# Altium schematic sheet P01_PMIC.SchDoc(P01_PMIC)
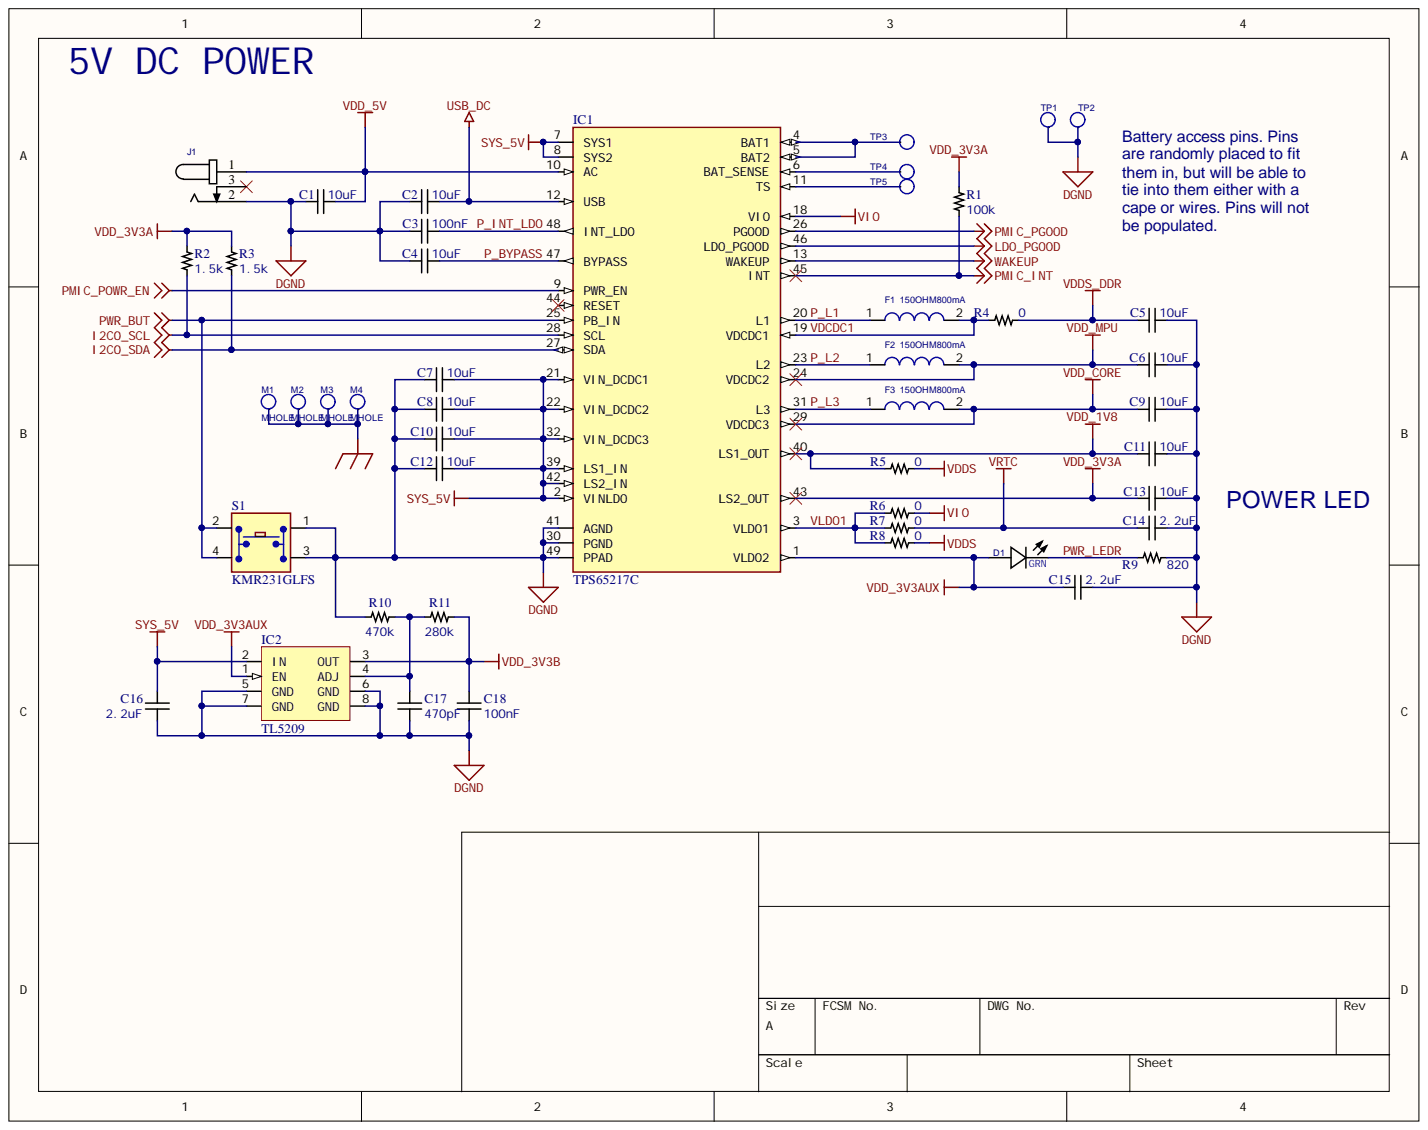
[diagram p0: P01_PMIC.SchDoc]

## Parts
C1  Capacitance: 10uF  [CAP0805]  (hidden comment: C2012X6S1A106K085AC)
C2  Capacitance: 10uF  [CAP0805]  (hidden comment: C2012X6S1A106K085AC)
C3  Capacitance: 100nF  [CAP0402]  (hidden comment: C0402C104K4RACTU)
C4  Capacitance: 10uF  [CAP0805]  (hidden comment: C2012X6S1A106K085AC)
C5  Capacitance: 10uF  [CAP0805]  (hidden comment: C2012X6S1A106K085AC)
C6  Capacitance: 10uF  [CAP0805]  (hidden comment: C2012X6S1A106K085AC)
C7  Capacitance: 10uF  [CAP0805]  (hidden comment: C2012X6S1A106K085AC)
C8  Capacitance: 10uF  [CAP0805]  (hidden comment: C2012X6S1A106K085AC)
C9  Capacitance: 10uF  [CAP0805]  (hidden comment: C2012X6S1A106K085AC)
C10  Capacitance: 10uF  [CAP0805]  (hidden comment: C2012X6S1A106K085AC)
C11  Capacitance: 10uF  [CAP0805]  (hidden comment: C2012X6S1A106K085AC)
C12  Capacitance: 10uF  [CAP0805]  (hidden comment: C2012X6S1A106K085AC)
C13  Capacitance: 10uF  [CAP0805]  (hidden comment: C2012X6S1A106K085AC)
C14  Capacitance: 2.2uF  [CAP0402]  (hidden comment: C1005X5R0J225K050BC)
C15  Capacitance: 2.2uF  [CAP0402]  (hidden comment: C1005X5R0J225K050BC)
C16  Capacitance: 2.2uF  [CAP0402]  (hidden comment: C1005X5R0J225K050BC)
C17  Capacitance: 470pF  [CAP0402]  (hidden comment: GRM155R71H471KA01D)
C18  Capacitance: 100nF  [CAP0402]  (hidden comment: C0402C104K4RACTU)
D1  598-8170-107F  [LED_0603]  (value not drawn)
F1  150OHM800mA  [FB0805]
F2  150OHM800mA  [FB0805]
F3  150OHM800mA  [FB0805]
IC1  TPS65217C  [PVQFN-48]
IC2  TL5209  [SOIC-8]
J1  PJ-200A  [CONN3-PWR-PJ102A]  (value not drawn)
M1  MHOLE  [MTGH200C125D_PL]  (no BOM)
M2  MHOLE  [MTGH200C125D_PL]  (no BOM)
M3  MHOLE  [MTGH200C125D_PL]  (no BOM)
M4  MHOLE  [MTGH200C125D_PL]  (no BOM)
R1  Resistance: 100k  [RES0402]  (hidden comment: RC0402FR-07100KL)
R2  Resistance: 1.5k  [RES0402]  (hidden comment: RC0402FR-071K5L)
R3  Resistance: 1.5k  [RES0402]  (hidden comment: RC0402FR-071K5L)
R4  RC0402JR-070RL  [RES0402]  (value not drawn)
R5  RC0402JR-070RL  [RES0402]  (value not drawn)
R6  RC0402JR-070RL  [RES0402]  (value not drawn)
R7  RC0402JR-070RL  [RES0402]  (value not drawn)
R8  RC0402JR-070RL  [RES0402]  (value not drawn)
R9  RC0402FR-07820RL  [RES0402]  (value not drawn)
R10  Resistance: 470k  [RES0402]  (hidden comment: RC0402FR-07470KL)
R11  Resistance: 280k  [RES0402]  (hidden comment: RC0402FR-07280KL)
S1  KMR231GLFS  [SW4SMD_63X161LS]
TP1  TESTPT1  [tp1-8040]  (value not drawn)  (no BOM)
TP2  TESTPT1  [tp1-8040]  (value not drawn)  (no BOM)
TP3  TESTPT1  [tp1-8040]  (value not drawn)  (no BOM)
TP4  TESTPT1  [tp1-8040]  (value not drawn)  (no BOM)
TP5  TESTPT1  [tp1-8040]  (value not drawn)  (no BOM)

## Nets
DGND: C1.2 C2.2 C3.2 C4.2 C5.1 C6.1 C7.2 C8.2 C9.1 C10.2 C11.1 C12.2 C13.1 C14.1 C15.1 C16.2 C17.2 C18.2 IC1.30(PGND) IC1.41(AGND) IC1.49(PPAD) IC2.5(GND) IC2.6(GND) IC2.7(GND) IC2.8(GND) J1.2 R9.2 R10.1 S1.1 S1.3 TP1.1 TP2.1
GND_EARTH: M1.1 M2.1 M3.1 M4.1
I2C0_SCL: IC1.28(SCL) R2.1
I2C0_SDA: IC1.27(SDA) R3.1
LDO_PGOOD: IC1.46(LDO_PGOOD)
PMIC_INT: IC1.45(INT) R1.1
PMIC_PGOOD: IC1.26(PGOOD)
PMIC_POWR_EN: IC1.9(PWR_EN)
PWR_BUT: IC1.25(PB_IN) S1.2 S1.4
SYS_5V: C7.1 C8.1 C10.1 C12.1 C16.1 IC1.2(VINLDO) IC1.7(SYS1) IC1.8(SYS2) IC1.21(VIN_DCDC1) IC1.22(VIN_DCDC2) IC1.32(VIN_DCDC3) IC1.39(LS1_IN) IC1.42(LS2_IN) IC2.2(IN)
USB_DC: C2.1 IC1.12(USB)
VDD_1V8: C11.2 IC1.40(LS1_OUT) R5.1
VDD_3V3A: C13.2 IC1.43(LS2_OUT) R1.2 R2.2 R3.2
VDD_3V3AUX: C15.2 D1.2 IC1.1(VLDO2) IC2.1(EN)
VDD_3V3B: C18.1 IC2.3(OUT) R11.2
VDD_5V: C1.1 IC1.10(AC) J1.1
VDD_CORE: C9.2 F3.2 IC1.29(VDCDC3)
VDD_MPU: C6.2 F2.2 IC1.24(VDCDC2)
VDDS: R5.2 R8.2
VDDS_DDR: C5.2 R4.2
VIO: IC1.18(VIO) R6.2
VRTC: C14.2 R7.2
WAKEUP: IC1.13(WAKEUP)
P_BYPASS: C4.1 IC1.47(BYPASS)
P_INT_LDO: C3.1 IC1.48(INT_LDO)
P_L1: F1.1 IC1.20(L1)
P_L2: F2.1 IC1.23(L2)
P_L3: F3.1 IC1.31(L3)
PWR_LEDR: D1.1 R9.1
VDCDC1: F1.2 IC1.19(VDCDC1) R4.1
VLDO1: IC1.3(VLDO1) R6.1 R7.1 R8.1
NetC17_1: C17.1 IC2.4(ADJ) R10.2 R11.1
NetIC1_4: IC1.4(BAT1) IC1.5(BAT2) TP3.1
NetIC1_6: IC1.6(BAT_SENSE) TP4.1
NetIC1_11: IC1.11(TS) TP5.1

Not connected: IC1 44(RESET); J1 3

## Library data of the parts
C1 C2 C4-C13 = CAP_10uF: MLCC 10uF 0805 SMD; Manufacturer: TDK; Voltage Rating (DC): 10V; Tolerance: 10%; Dielectric Material: Ceramic; Dielectric: X6S; Width: 0.049inch; Weight: 0.000194oz; Voltage Rating: 10V; Thickness: 0.037inch; Termination: SMD/SMT; Supplier Part Number 1: 445-7613-1-ND; Supplier 1: Digi-Key; Stock 1: 46852; RoHS: Yes; REACH SVHC: No SVHC; Pricing 1: 1=0.30000, 10=0.20500, 100=0.11610, 500=0.08198, 1000=0.07173 (USD); Packaging: Tape and Reel; Package Quantity: 4000; Mount: Surface Mount; Min Operating Temperature: -55°C; Max Operating Temperature: 105°C; Manufacturer Part Number: C2012X6S1A106K085AC; Length: 2mm; Lead Free: Lead Free; Height: 1.25mm; Depth: 1.25mm; Composition: Ceramic; ComponentLink3URL: https://datasheet.ciiva.com/6290/tdkmlcccaprange-6290501.pdf?src-supplier=Digi-Key; ComponentLink3Description: Datasheet; Component Kind: Standard; Case Code (Metric): 2012; Case Code (Imperial): 0805; Case/Package: 0805; Library Name: Orient.SchLib
C3 C18 = CAP_100nF: MLCC 100nF 0402 SMD; Manufacturer: KEMET; Voltage Rating (DC): 16V; Tolerance: 10%; Dissipation Factor: 3.5%; Dielectric: X7R; Width: 0.5mm; Voltage Rating: 16V; Termination: SMD/SMT; Supplier Part Number 1: 399-3521-1-ND; Supplier 1: Digi-Key; Stock 1: 6512243; RoHS: Yes; Radiation Hardening: No; Pricing 1: 1=0.10000, 10=0.04200, 100=0.01860, 500=0.01328, 1000=0.01044, 2500=0.00949, 5000=0.00873 (USD); Packaging: Tape and Reel; Package Quantity: 10000; Mount: Surface Mount; Min Operating Temperature: -55°C; Max Operating Temperature: 125°C; Manufacturer Part Number: C0402C104K4RACTU; Length: 1mm; Height: 0.5mm; Depth: 0.5mm; Contact Plating: Tin,Matte; ComponentLink2URL: https://datasheet.ciiva.com/18192/getdatasheetpartid-385384-18192412.pdf?src-supplier=Verical; ComponentLink2Description: Datasheet; Component Kind: Standard; Case Code (Metric): 1005; Case Code (Imperial): 0402; Case/Package: 0402; Library Name: Orient.SchLib
C14-C16 = CAP_2.2uF: MLCC 2.2uF 0402 SMD; Manufacturer: TDK; Voltage Rating (DC): 6.3V; Tolerance: 10%; Dielectric Material: Ceramic; Dielectric: X5R; Width: 0.02inch; Weight: 2.3E-05oz; Voltage Rating: 6.3V; Voltage: 6.3V; Thickness: 0.022inch; Termination: SMD/SMT; Supplier 1: Digi-Key; Stock 1: 256055; RoHS: Yes; REACH SVHC: No SVHC; Radiation Hardening: No; Pricing 1: 1=0.20000, 10=0.13800, 100=0.07010, 500=0.04794, 1000=0.04240, 2500=0.04056, 5000=0.03687 (USD); Packaging: Tape and Reel; Package Quantity: 1; Number of Pins: 2; Mount: Surface Mount; Min Operating Temperature: -55°C; Max Operating Temperature: 85°C; Manufacturer Part Number: C1005X5R0J225K050BC; Length: 1mm; Lead Free: Lead Free; Height: 0.5mm; Depth: 0.5mm; Composition: Ceramic; ComponentLink7URL: https://product.tdk.com/info/en/catalog/datasheets/mlcc_commercial_general_en.pdf?ref_disty=digikey; ComponentLink7Description: Datasheet; Component Kind: Standard; Case Code (Metric): 1005; Case Code (Imperial): 0402; Case/Package: 0402; Library Name: Orient.SchLib
C17 = CAP_470pF: MLCC 470pF 0402 SMD; Manufacturer: Murata; Voltage Rating (DC): 50V; Tolerance: 10%; Material: Ceramic; Dielectric: X7R; Width: 0.02inch; Voltage Rating: 50V; Voltage: 50V; Thickness: 0.022inch; Termination: SMD/SMT; Supplier Part Number 1: 490-1300-1-ND; Supplier 1: Digi-Key; Stock 1: 1824670; RoHS: Yes; REACH SVHC: No SVHC; Radiation Hardening: No; Pricing 1: 1=0.10000, 10=0.04900, 100=0.02200, 500=0.01572, 1000=0.01235, 2500=0.01122, 5000=0.01033 (USD); Packaging: Tape and Reel; Package Quantity: 1; Number of Pins: 2; Mount: Surface Mount; Min Operating Temperature: -55°C; Max Operating Temperature: 125°C; Manufacturer Part Number: GRM155R71H471KA01D; Length: 1mm; Lead Free: Lead Free; Height: 0.5mm; Depth: 0.5mm; ComponentLink11URL: https://datasheet.ciiva.com/7937/608985-7937630.pdf?src-supplier=Element14; ComponentLink11Description: https://datasheet.ciiva.com/7937/608985-7937630.pdf?src-supplier=Element14; ComponentLink10URL: https://datasheet.ciiva.com/16199/product-16199180.pdf?src-supplier=Future+Electronics; ComponentLink10Description: https://datasheet.ciiva.com/16199/product-16199180.pdf?src-supplier=Future+Electronics; ComponentLink9URL: https://datasheet.ciiva.com/22698/608985-22698425.pdf?src-supplier=Element14; ComponentLink9Description: https://datasheet.ciiva.com/22698/608985-22698425.pdf?src-supplier=Element14; ComponentLink8URL: https://datasheet.ciiva.com/14116/grmseries-highdielectrictype-eng-14116837.pdf?src-supplier=Distrelec; ComponentLink8Description: https://datasheet.ciiva.com/14116/grmseries-highdielectrictype-eng-14116837.pdf?src-supplier=Distrelec; ComponentLink7URL: https://datasheet.ciiva.com/129/partnumbering-e-01-129845.pdf?src-supplier=Digi-Key; ComponentLink7Description: https://datasheet.ciiva.com/129/partnumbering-e-01-129845.pdf?src-supplier=Digi-Key; ComponentLink6URL: https://datasheet.ciiva.com/129/partnumbering-e-01-129844.pdf?src-supplier=Digi-Key; ComponentLink6Description: https://datasheet.ciiva.com/129/partnumbering-e-01-129844.pdf?src-supplier=Digi-Key; ComponentLink5URL: https://datasheet.ciiva.com/129/partnumbering-e-01-129843.pdf?src-supplier=Digi-Key; ComponentLink5Description: https://datasheet.ciiva.com/129/partnumbering-e-01-129843.pdf?src-supplier=Digi-Key; ComponentLink4URL: https://datasheet.ciiva.com/29199/454340-da-01-en-vs-kondensator-0402-29199656.pdf?src-supplier=Conrad; ComponentLink4Description: https://datasheet.ciiva.com/29199/454340-da-01-en-vs-kondensator-0402-29199656.pdf?src-supplier=Conrad; ComponentLink3URL: https://datasheet.ciiva.com/19476/1856505-19476137.pdf?src-supplier=Jameco; ComponentLink3Description: https://datasheet.ciiva.com/19476/1856505-19476137.pdf?src-supplier=Jameco; ComponentLink2URL: https://datasheet.ciiva.com/18101/getdatasheetpartid-37722-18101030.pdf?src-supplier=Verical; ComponentLink2Description: https://datasheet.ciiva.com/18101/getdatasheetpartid-37722-18101030.pdf?src-supplier=Verical; ComponentLink1URL: https://datasheet.ciiva.com/11786/0900766b80ef921d-11786912.pdf?src-supplier=RSComponents; ComponentLink1Description: https://datasheet.ciiva.com/11786/0900766b80ef921d-11786912.pdf?src-supplier=RSComponents; Component Kind: Standard; Case Code (Metric): 1005; Case Code (Imperial): 0402; Case/Package: 0402; Library Name: Orient.SchLib
D1 = LED_LTST-C150GKT: LED GREEN CLEAR 0805 SMD; Manufacturer Part Number: LTST-C170KGKT; Manufacturer: Lite-On Inc; Wavelength - Peak: 574nm; Wavelength - Dominant: 571nm; Voltage - Forward (Vf) Typ: 2V; Viewing Angle: 130°; TN: 257-0020-000; Millicandela Rating: 35mcd; Lens Style/Size: Rectangle with Flat Top, 1.4mm x 1.25mm; Current - Test: 20mA; CLASS: DISCRETE; Supplier Part Number 1: 160-1414-6-ND; Supplier 1: Digi-Key; Stock 1: 274890; Size / Dimension: 2.00mm L x 1.25mm W; RoHS: RoHS Compliant; Pricing 1: 1=0.37, 10=0.267, 100=0.1574, 250=0.11136, 500=0.09504, 1000=0.07425 (USD); Packaging: Digi-Reel®; Package / Case: 0805 (2012 Metric); Mounting Type: Surface Mount; Luminous Flux @ Current - Test: -; Lens Type: Clear; Height: 1.10mm; ComponentLink1URL: http://optoelectronics.liteon.com/en-us/api/DwonloadFileHandler.ashx?txtSpecNo=DS22-2000-073&txtPartNo=LTST-C170KGKT; ComponentLink1Description: http://optoelectronics.liteon.com/en-us/api/DwonloadFileHandler.ashx?txtSpecNo=DS22-2000-073&txtPartNo=LTST-C170KGKT; Component Kind: Standard; Color: Green; Category: Optoelectronics; Library Name: Orient.SchLib
F1-F3 = IND: FERRITE 800MA 150 OHM 0805 SMD; Manufacturer Part Number: LI0805H151R-10; Manufacturer: Laird-Signal Integrity Products; Impedance @ Frequency: 150 Ohm @ 100MHz; Filter Type: Differential Mode - Single; DC Resistance (DCR): 150 mOhm Max; Supplier Part Number 1: 240-2384-6-ND; Supplier 1: Digi-Key; Stock 1: 10144; Size / Dimension: 0.079" L x 0.049" W (2.00mm x 1.25mm); RoHS: RoHS Compliant; Pricing 1: 1=0.1, 10=0.07, 25=0.064, 50=0.0574, 100=0.0421, 250=0.03064, 500=0.02808, 1000=0.02424 (USD); Packaging: Digi-Reel®; Package / Case: 0805 (2012 Metric); Mounting Type: Surface Mount; Height (Max): 0.043" (1.10mm); Current Rating: 800mA; ComponentLink1URL: http://www.lairdtech.com/WorkArea/linkit.aspx?LinkIdentifier=id&ItemID=4912; ComponentLink1Description: http://www.lairdtech.com/WorkArea/linkit.aspx?LinkIdentifier=id&ItemID=4912; Component Kind: Standard; Category: Filters; Library Name: Orient.SchLib
IC1 = TPS65217C: IC PMIC BATT PWR SYST 48VQFN; Manufacturer Part Number: TPS65217CRSLR; Manufacturer: Texas Instruments; Voltage - Supply: 2.75 V ~ 5.8 V; Supplier Part Number 1: 296-34814-6-ND; Supplier Device Package: 48-VQFN-EP (6x6); Supplier 1: Digi-Key; Stock 1: 0; RoHS: RoHS Compliant; Pricing 1: 1=8.01, 10=7.204, 25=6.5516, 100=4.1918, 250=4.11412, 500=4.0365, 750=3.95888 (USD); Packaging: Digi-Reel®; Package / Case: 48-VFQFN Exposed Pad; Operating Temperature: -40°C ~ 105°C; Mounting Type: Surface Mount; Current - Supply: -; ComponentLink1URL: http://www.ti.com/lit/ds/slvsb64i/slvsb64i.pdf; ComponentLink1Description: Datasheet; Component Kind: Standard; Category: Integrated Circuits (ICs); Applications: Battery Management, Display (LED Drivers), Handheld/Mobile Devices, Power Supply; Library Name: Orient.SchLib
IC2 = TL5209: LDO Voltage Regulator; Manufacturer Part Number: TL5209DR; Manufacturer: Texas Instruments; Voltage - Output: 2.5 V ~ 6.5 V; Voltage - Input: 2.5 V ~ 16 V; Voltage - Dropout (Typical): 0.35V @ 500mA; Regulator Topology: Positive Adjustable; Number of Regulators: 1; Current - Output: 500mA (Max); Supplier Part Number 1: 296-21569-6-ND; Supplier Device Package: 8-SOIC; Supplier 1: Digi-Key; Stock 1: 7190; RoHS: RoHS Compliant; Pricing 1: 1=1.41, 10=1.244, 25=1.1232, 100=0.9828, 250=0.86192, 500=0.7644, 1000=0.6045 (USD); Packaging: Digi-Reel®; Package / Case: 8-SOIC (0.154", 3.90mm Width); Operating Temperature: -40°C ~ 125°C; Mounting Type: Surface Mount; Current - Limit (Min): -; ComponentLink1URL: http://media.digikey.com/pdf/Data%20Sheets/Texas%20Instruments%20PDFs/TL5209.pdf; ComponentLink1Description: http://media.digikey.com/pdf/Data%20Sheets/Texas%20Instruments%20PDFs/TL5209.pdf; Component Kind: Standard; Category: Integrated Circuits (ICs); Library Name: Orient.SchLib
J1 = Power_Jack: CONN PWR JACK 2.1X5.5MM HIGH CUR; Manufacturer Part Number: PJ-002AH; Manufacturer: CUI Inc; Shielding: Unshielded; Plug/Mating Plug Diameter: 2.1mm ID, 5.5mm OD; Number of Positions/Contacts: 2 Conductors, 3 Contacts; Internal Switch(s): Single Switch, Normally Closed; Gender: Male; Current Rating: 5A; Termination: Solder Eyelet(s); Supplier Part Number 1: CP-002AH-ND; Supplier 1: Digi-Key; Stock 1: 81962; RoHS: RoHS Compliant; Pricing 1: 1=1.01, 10=0.704, 100=0.4724, 500=0.3819, 1000=0.3417, 2500=0.27135 (USD); Operating Temperature: -25°C ~ 85°C; Mounting Type: Through Hole, Right Angle; Housing Material: Thermoplastic; Housing Color: Black; Features: -; Contact Material - Plating: Nickel; Contact Material: Copper Alloy; Connector Type: Jack; ComponentLink1URL: http://www.cui.com/Product/Resource/DigiKeyPDF/PJ-002AH.pdf; ComponentLink1Description: http://www.cui.com/Product/Resource/DigiKeyPDF/PJ-002AH.pdf; Component Kind: Standard; Color: Black; Category: Connectors, Interconnects; Library Name: Orient.SchLib
R1 = RES_100k: Resistor 100kOhm 0402 SMD; Manufacturer: Yageo; Working Voltage: 50V; Tolerance: 1%; Temperature Coefficient: 100ppm/°C; Power Rating: 63mW; Width: 0.02inch; Voltage Rating: 50V; Termination: SMD/SMT; Supplier Part Number 2: 311-100KLRCT-ND; Supplier Part Number 1: 603-RC0402FR-07100KL; Supplier 2: Digi-Key; Supplier 1: Mouser; RoHS: Yes; REACH SVHC: No SVHC; Packaging: Tape and Reel; Package Quantity: 1; Number of Terminations: 2; Min Operating Temperature: -55°C; Military Standard: Not; Max Power Dissipation: 63mW; Max Operating Temperature: 155°C; Manufacturer Part Number: RC0402FR-07100KL; Length: 0.039inch; Lead Free: Lead Free; Height: 0.016inch; Features: Moisture Resistant; Depth: 0.5mm; Composition: Thick Film; ComponentLink2URL: https://www.yageo.com/upload/media/product/productsearch/datasheet/rchip/PYu-RC_Group_51_RoHS_L_10.pdf; ComponentLink2Description: Datasheet; Component Kind: Standard; Case Code (Metric): 1005; Case Code (Imperial): 0402; Case/Package: 0402; Library Name: Orient.SchLib
R2 R3 = RES_1.5k: Resistor 1.5kOhm 0402 SMD; Manufacturer: Yageo; Voltage Rating: 50V; Tolerance: 1%; Temperature Coefficient: 100ppm/°C; Power Rating: 62.5mW; Max Power Dissipation: 63mW; Width: 0.02inch; Termination: SMD/SMT; Supplier Part Number 2: 603-RC0402FR-071K5L; Supplier Part Number 1: 311-1.50KLRCT-ND; Supplier 2: Mouser; Supplier 1: Digi-Key; RoHS: Yes; REACH SVHC: No SVHC; Packaging: Tape and Reel; Package Quantity: 1; Number of Terminations: 2; Min Operating Temperature: -55°C; Military Standard: Not; Max Operating Temperature: 155°C; Manufacturer Part Number: RC0402FR-071K5L; Length: 1mm; Lead Free: Lead Free; Height: 0.016inch; Features: Moisture Resistant; Depth: 0.5mm; Composition: Thick Film; ComponentLink1URL: https://www.yageo.com/upload/media/product/productsearch/datasheet/rchip/PYu-RC_Group_51_RoHS_L_10.pdf; ComponentLink1Description: Datasheet; Component Kind: Standard; Case Code (Metric): 1005; Case Code (Imperial): 0402; Case/Package: 0402; Library Name: Orient.SchLib
R4-R8 = RES_0: Resistor 0Ohm 0402 SMD; Manufacturer: Yageo; Voltage Rating: 50V; Tolerance: 5%; Temperature Coefficient: 200ppm/°C; Resistance: 0; Power Rating: 62.5mW; Max Power Dissipation: 63mW; Width: 0.02inch; Termination: SMD/SMT; Supplier Part Number 1: 311-0.0JRCT-ND; Supplier 1: Digi-Key; Stock 1: 68160806; RoHS: Yes; REACH SVHC: Unknown; Radiation Hardening: No; Pricing 1: 1=0.10000, 10=0.01300, 25=0.00920, 50=0.00700, 100=0.00510, 250=0.00392, 500=0.00312, 1000=0.00230, 5000=0.00165 (USD); Packaging: Tape and Reel; Package Quantity: 1; Number of Terminations: 2; Min Operating Temperature: -55°C; Military Standard: Not; Max Operating Temperature: 155°C; Manufacturer Part Number: RC0402JR-070RL; Length: 1mm; Lead Free: Lead Free; Height: 0.016inch; Features: Moisture Resistant; Depth: 0.5mm; Contact Plating: Tin,Matte; Composition: Thick Film; ComponentLink2URL: https://www.yageo.com/upload/media/product/productsearch/datasheet/rchip/PYu-RC_Group_51_RoHS_L_10.pdf; ComponentLink2Description: Datasheet; ComponentLink1URL: https://www.digikey.com/product-detail/en/yageo/RC0402JR-070RL/311-0.0JRCT-ND/729353; ComponentLink1Description: Digikey; Component Kind: Standard; Case Code (Metric): 1005; Case Code (Imperial): 0402; Case/Package: 0402; Library Name: Orient.SchLib
R9 = RES_820: Resistor 820Ohm 0402 SMD; Manufacturer: Yageo; Voltage Rating: 50V; Tolerance: 1%; Temperature Coefficient: 100ppm/°C; Resistance: 820; Power Rating: 63mW; Width: 0.5mm; Termination: SMD/SMT; Supplier Part Number 1: 311-820LRCT-ND; Supplier 1: Digi-Key; Stock 1: 1640857; RoHS: Yes; REACH SVHC: Unknown; Pricing 1: 1=0.10000, 10=0.01600, 100=0.00640, 1000=0.00289, 2500=0.00251, 5000=0.00207 (USD); Packaging: Tape and Reel; Package Quantity: 1; Number of Terminations: 2; Min Operating Temperature: -55°C; Military Standard: Not; Max Power Dissipation: 63mW; Max Operating Temperature: 155°C; Manufacturer Part Number: RC0402FR-07820RL; Length: 1mm; Lead Free: Lead Free; Height: 0.016inch; Features: Moisture Resistant; Depth: 0.5mm; Composition: Thick Film; Component Kind: Standard; Case Code (Metric): 1005; Case Code (Imperial): 0402; Case/Package: 0402; Library Name: Orient.SchLib
R10 = RES_470k: Resistor 470kOhm 0402 SMD; Manufacturer: Yageo; Voltage Rating (DC): 50V; Tolerance: 1%; Temperature Coefficient: 100ppm/°C; Power Rating: 62.5mW; Max Power Dissipation: 63mW; Width: 0.02inch; Voltage Rating: 50V; Termination: SMD/SMT; Supplier Part Number 1: 311-470KLRCT-ND; Supplier 1: Digi-Key; Stock 1: 2249859; RoHS: Yes; REACH SVHC: No SVHC; Pricing 1: 1=0.10000, 10=0.01600, 100=0.00640, 1000=0.00289, 2500=0.00251, 5000=0.00207 (USD); Packaging: Tape and Reel; Package Quantity: 1; Number of Terminations: 2; Number of Pins: 2; Min Operating Temperature: -55°C; Military Standard: Not; Max Operating Temperature: 155°C; Manufacturer Part Number: RC0402FR-07470KL; Length: 1mm; Lead Free: Lead Free; Height: 0.016inch; Features: Moisture Resistant; Depth: 0.5mm; Composition: Thick Film; ComponentLink1URL: https://www.yageo.com/upload/media/product/productsearch/datasheet/rchip/PYu-RC_Group_51_RoHS_L_10.pdf; ComponentLink1Description: Datasheet; Component Kind: Standard; Case Code (Metric): 1005; Case Code (Imperial): 0402; Case/Package: 0402; Library Name: Orient.SchLib
R11 = RES_280k: Resistor 280kOhm 0402 SMD; Manufacturer: Yageo; Voltage Rating (DC): 50V; Tolerance: 1%; Temperature Coefficient: 100ppm/°C; Power Rating: 62.5mW; Max Power Dissipation: 63mW; Width: 0.5mm; Voltage Rating: 50V; Termination: SMD/SMT; Supplier Part Number 1: YAG3087CT-ND; Supplier 1: Digi-Key; Stock 1: 1301983; RoHS: Yes; Radiation Hardening: No; Pricing 1: 1=0.10000, 10=0.01600, 100=0.00640, 1000=0.00289, 2500=0.00251, 5000=0.00207 (USD); Packaging: Tape and Reel; Number of Terminations: 2; Min Operating Temperature: -55°C; Military Standard: Not; Max Operating Temperature: 155°C; Manufacturer Part Number: RC0402FR-07280KL; Length: 1mm; Lead Free: Lead Free; Height: 0.016inch; Features: Moisture Resistant; Depth: 0.5mm; Contact Plating: Tin,Matte,Lead; Composition: Thick Film; ComponentLink1URL: https://www.yageo.com/upload/media/product/productsearch/datasheet/rchip/PYu-RC_Group_51_RoHS_L_10.pdf; ComponentLink1Description: Datasheet; Component Kind: Standard; Case Code (Metric): 1005; Case Code (Imperial): 0402; Case/Package: 0402; Library Name: Orient.SchLib
S1 = KMR2: Microminiature Tact Switch for SMT; Manufacturer: C&K Components; Switch Travel: 0.25mm; Switch Function: Off-Mom; Outline: 4.60mm x 2.80mm; Operating Force: 300gf; Mechanical Life: 150,000 Cycles; Illumination: Non-Illuminated; Contact Rating @ Voltage: 0.05A @ 32VDC; Circuit: SPST-NO; Actuator Type: Standard; Actuator Orientation: Top Actuated; Actuator Height off PCB, from Pins: 1.90mm; Termination Style: Gull Wing; Supplier Part Number 1: 401-1429-6-ND; Supplier 1: Digi-Key; Stock 1: 32289; RoHS: RoHS Compliant; Pricing 1: 1=0.44, 10=0.416, 25=0.3744, 50=0.3326, 100=0.3188, 250=0.29108, 500=0.2772, 1000=0.22869 (USD); Packaging: Digi-Reel®; Operating Temperature: -40°C ~ 85°C; Mounting Type: Surface Mount; Manufacturer Part Number: KMR231GLFS; Library Name: Orient.SchLib; Ingress Protection: -; Illumination Voltage (Nominal): -; Illumination Type, Color: -; Features: Grounding Pin; ComponentLink1URL: http://www.ck-components.com/index.php?module=media&action=Display&cmpref=14414&lang=en&width=&height=&format=&alt=; ComponentLink1Description: http://www.ck-components.com/index.php?module=media&action=Display&cmpref=14414&lang=en&width=&height=&format=&alt=; Component Kind: Standard; Category: Switches; Actuator Material: -
M1-M4 = MHOLE: Library Name: Orient.SchLib
TP1-TP5 = TESTPT1: Library Name: Orient.SchLib
